# Altium schematic sheet POWER.SchDoc(POWER)
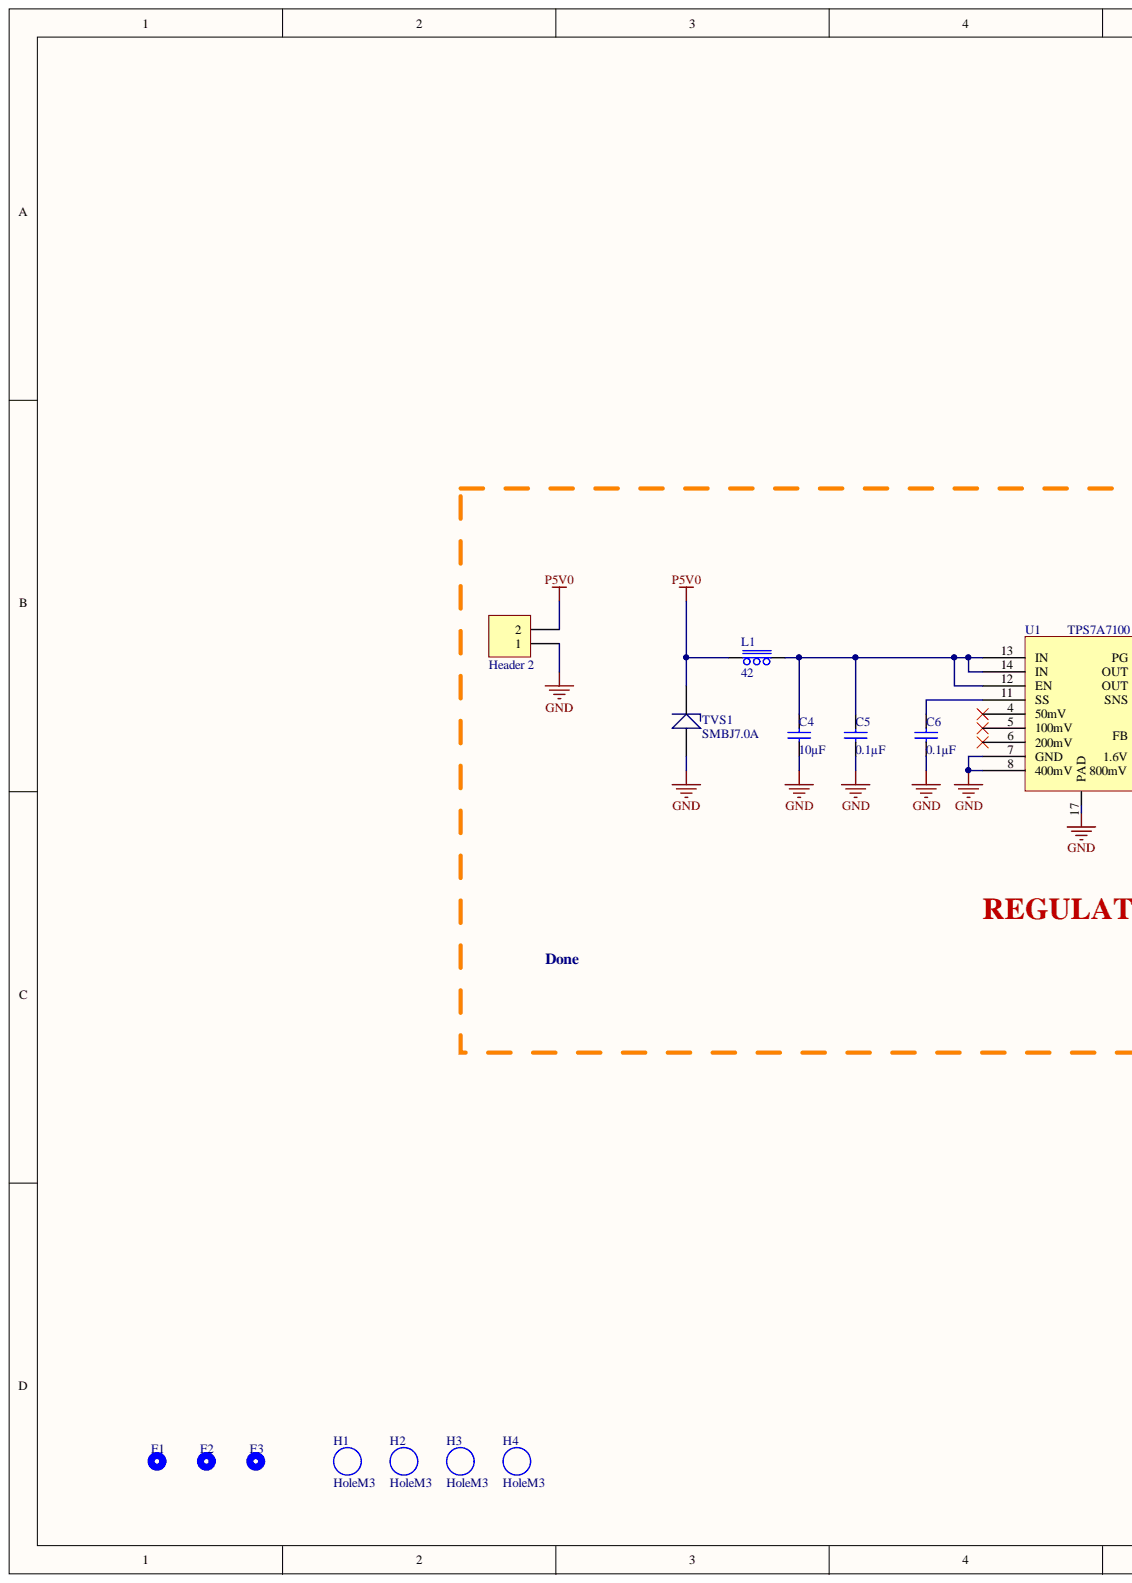
[diagram p0: POWER.SchDoc, left]
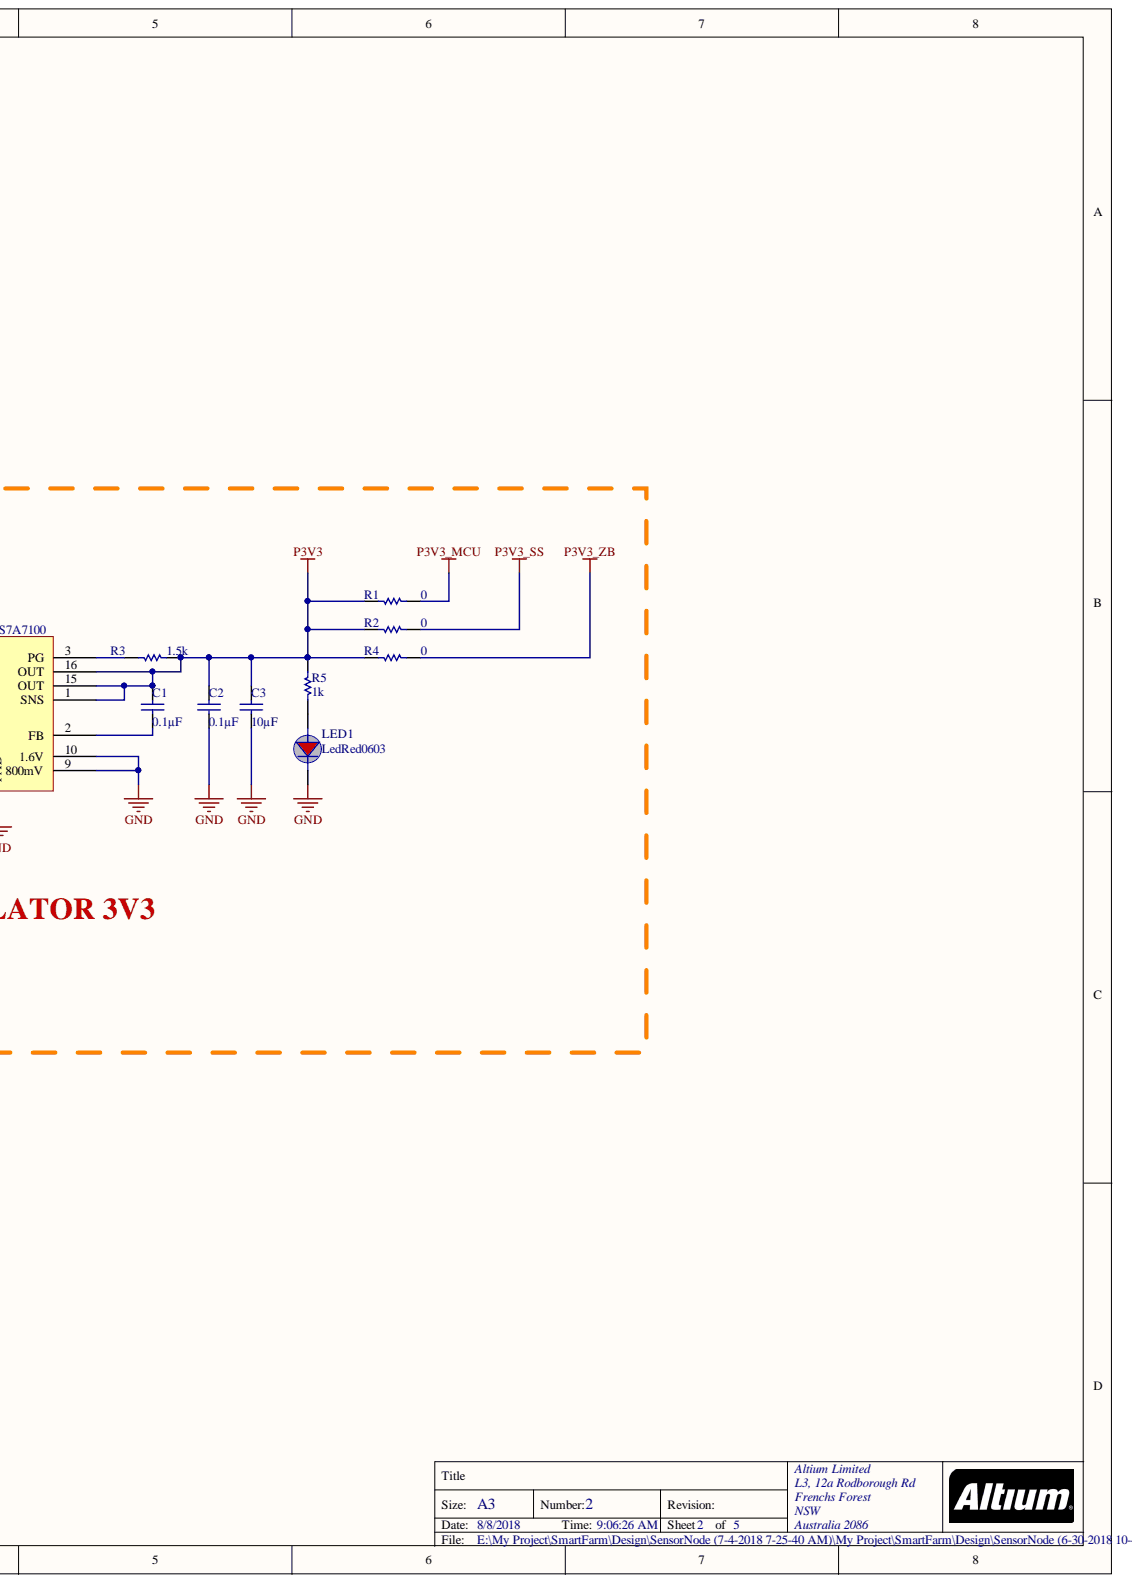
[diagram p1: POWER.SchDoc, right]

## Parts
C1  0.1µF  [C0402M]
C2  0.1µF  [C0402M]
C3  10µF  [0805 cap]
C4  10µF  [0805 cap]
C5  0.1µF  [C0402M]
C6  0.1µF  [C0402M]
F1  (no value)  [fiducials]
F2  (no value)  [fiducials]
F3  (no value)  [fiducials]
H1  HoleM3  [HOLEM3via]
H2  HoleM3  [HOLEM3via]
H3  HoleM3  [HOLEM3via]
H4  HoleM3  [HOLEM3via]
L1  42  [0805F]
LED1  LedRed0603  [0603LED-RED]
R1  0  [R0805M]
R2  0  [R0805M]
R3  1.5k  [R0603M]
R4  0  [R0805M]
R5  1k  [R0603M]
TVS1  SMBJ7.0A  [DIOM4436X26N]
U1  TPS7A7100  [QFN16]
  Header 2  [HED2T]

## Nets
GND: C2.1 C3.1 C4.1 C5.1 C6.1 LED1.2 TVS1.1 U1.7(GND) U1.8(400mV) U1.9(800mV) U1.10(1.6V) U1.17(PAD) .1
P3V3: C1.2 C2.2 C3.2 R1.1 R2.1 R3.2 R4.1 R5.2 U1.1(SNS) U1.15(OUT) U1.16(OUT)
P3V3_MCU: R1.2
P3V3_SS: R2.2
P3V3_ZB: R4.2
P5V0: L1.1 TVS1.2 .2
NetC1_1: C1.1 U1.2(FB)
NetC4_2: C4.2 C5.2 L1.2 U1.12(EN) U1.13(IN) U1.14(IN)
NetC6_2: C6.2 U1.11(SS)
NetLED1_1: LED1.1 R5.1
NetR3_1: R3.1 U1.3(PG)

Not connected: U1 4(50mV) 5(100mV) 6(200mV)

## Library data of the parts
C1 C2 C5 C6 = CAP0.1u0402: CAP CER 0.1UF 10V X7R 0402; Manufacturer Part Number: LMK105B7104KVHF; Manufacturer: Taiyo Yuden; Tolerance: ±10%; Voltage - Rated: 10V; Thickness (Max): 0.022" (0.55mm); Temperature Coefficient: X7R; Supplier Part Number 1: 587-3769-1-ND; Supplier 1: Digi-Key; Stock 1: 23930; Size / Dimension: 0.039" L x 0.020" W (1.00mm x 0.50mm); RoHS: RoHS Compliant; Ratings: AEC-Q200; Pricing 1: 1=0.1, 10=0.05, 100=0.0223, 500=0.01594, 1000=0.01252, 2500=0.01138, 5000=0.01047 (USD); Part Status: Active; Packaging: Cut Tape (CT); Package / Case: 0402 (1005 Metric); Operating Temperature: -55°C ~ 125°C; Mounting Type: Surface Mount, MLCC; Lead Style: -; Lead Spacing: -; Height - Seated (Max): -; Features: -; ComponentLink1URL: http://www.yuden.co.jp/productdata/catalog/en/mlcc_all_hq_e.pdf; ComponentLink1Description: http://www.yuden.co.jp/productdata/catalog/en/mlcc_all_hq_e.pdf; Component Kind: Standard; Category: Capacitors; Capacitance: 0.1µF; Applications: Automotive; Library Name: BinhLib.IntLib
C3 C4 = cap10u0805: CAP CER 10UF 16V X7R 0805; Manufacturer Part Number: EMK212BB7106MG-T; Manufacturer: Taiyo Yuden; Tolerance: ±20%; Voltage - Rated: 16V; Thickness (Max): 0.053" (1.35mm); Temperature Coefficient: X7R; Supplier Part Number 1: 587-3319-1-ND; Supplier 1: Digi-Key; Stock 1: 221600; Size / Dimension: 0.079" L x 0.049" W (2.00mm x 1.25mm); RoHS: RoHS Compliant; Ratings: -; Pricing 1: 1=0.51, 10=0.351, 100=0.2106, 500=0.15798, 1000=0.1334 (USD); Part Status: Active; Packaging: Cut Tape (CT); Package / Case: 0805 (2012 Metric); Operating Temperature: -55°C ~ 125°C; Mounting Type: Surface Mount, MLCC; Lead Style: -; Lead Spacing: -; Height - Seated (Max): -; Features: -; ComponentLink1URL: http://www.yuden.co.jp/productdata/catalog/en/mlcc06_e.pdf; ComponentLink1Description: http://www.yuden.co.jp/productdata/catalog/en/mlcc06_e.pdf; Component Kind: Standard; Category: Capacitors; Capacitance: 10µF; Applications: General Purpose; Library Name: BinhLib.IntLib
F1-F3 = Fiducials: Component Kind: Standard; Library Name: BinhLib.IntLib
L1 = Ferrite Bead: FERRITE BEAD 42 OHM 0805 1LN; Manufacturer Part Number: FBMJ2125HS420-TV; Manufacturer: Taiyo Yuden; Number of Lines: 1; Impedance @ Frequency: 42 Ohm @ 100MHz; Filter Type: Power Line; DC Resistance (DCR) (Max): 8 mOhm; Current Rating (Max): 4A; Supplier Part Number 1: 587-3851-6-ND; Supplier 1: Digi-Key; Stock 1: 2013; Size / Dimension: 0.079" L x 0.049" W (2.00mm x 1.25mm); RoHS: RoHS Compliant; Ratings: AEC-Q200; Pricing 1: 1=0.2, 10=0.154, 25=0.1276, 50=0.11, 100=0.099, 250=0.0836, 500=0.0748, 1000=0.0638 (USD); Part Status: Active; Packaging: Digi-Reel®; Package / Case: 0805 (2012 Metric); Operating Temperature: -40°C ~ 125°C; Mounting Type: Surface Mount; Height (Max): 0.041" (1.05mm); ComponentLink1URL: http://ds.yuden.co.jp/TYCOMPAS/ut/detail.do?productNo=FBMJ2125HS420-TV&fileName=FBMJ2125HS420-TV_SS&mode=specSheetDownload; ComponentLink1Description: http://ds.yuden.co.jp/TYCOMPAS/ut/detail.do?productNo=FBMJ2125HS420-TV&fileName=FBMJ2125HS420-TV_SS&mode=specSheetDownload; Component Kind: Standard; Category: Filters; Library Name: BinhLib.IntLib
LED1 = LedRed0603: LED RED CLEAR 0603 SMD; Manufacturer Part Number: QTLP600C7TR; Manufacturer: Everlight Electronics Co Ltd; Wavelength - Peak: 660nm; Wavelength - Dominant: 645nm; Voltage - Forward (Vf) (Typ): 1.9V; Viewing Angle: 100°; Millicandela Rating: 20mcd; Lens Style/Size: Rectangle with Flat Top, 1.20mm x 0.80mm; Lens Color: Colorless; Current - Test: 20mA; Supplier Part Number 1: 1080-1400-1-ND; Supplier 1: Digi-Key; Stock 1: 19325; Size / Dimension: 1.60mm L x 0.80mm W; RoHS: RoHS Compliant; Pricing 1: 1=0.41, 10=0.297, 25=0.2276, 100=0.1485, 250=0.10892, 500=0.0924, 1000=0.0759 (USD); Part Status: Active; Packaging: Cut Tape (CT); Package / Case: 0603 (1608 Metric); Mounting Type: Surface Mount; Lens Transparency: Clear; Height (Max): 0.80mm; Features: -; ComponentLink1URL: http://media.digikey.com/pdf/Data%20Sheets/Everlight%20PDFs/QTLP600C.pdf; ComponentLink1Description: http://media.digikey.com/pdf/Data%20Sheets/Everlight%20PDFs/QTLP600C.pdf; Component Kind: Standard; Color: Red; Category: Optoelectronics; Library Name: BinhLib.IntLib
R1 R2 R4 = RES00805: RES SMD 0.0 OHM JUMPER 1/8W 0805; Manufacturer Part Number: ERJ-6GEY0R00V; Manufacturer: Panasonic Electronic Components; Resistance (Ohms): 0.0; Power (Watts): 0.125W, 1/8W; Tolerance: Jumper; Temperature Coefficient: -; Supplier Part Number 1: P0.0ACT-ND; Supplier Device Package: 0805; Supplier 1: Digi-Key; Stock 1: 562130; Size / Dimension: 0.079" L x 0.049" W (2.00mm x 1.25mm); RoHS: RoHS Compliant; Pricing 1: 1=0.1, 10=0.024, 25=0.0172, 50=0.0132, 100=0.0097, 250=0.00744, 500=0.00594, 1000=0.00437 (USD); Part Status: Active; Packaging: Cut Tape (CT); Package / Case: 0805 (2012 Metric); Operating Temperature: -55°C ~ 155°C; Height - Seated (Max): 0.028" (0.70mm); Features: Automotive AEC-Q200; Failure Rate: -; Composition: Thick Film; ComponentLink1URL: http://industrial.panasonic.com/www-cgi/jvcr13pz.cgi?E+PZ+3+AOA0001+ERJ6GEY0R00V+7+WW; ComponentLink1Description: http://industrial.panasonic.com/www-cgi/jvcr13pz.cgi?E+PZ+3+AOA0001+ERJ6GEY0R00V+7+WW; Component Kind: Standard; Category: Resistors; Library Name: BinhLib.IntLib
R3 = RES1k50603: RES SMD 1.5K OHM 1% 1/10W 0603; Manufacturer Part Number: ERJ-3EKF1501V; Manufacturer: Panasonic Electronic Components; Temperature Coefficient: ±100ppm/°C; Power (Watts): 0.1W, 1/10W; Tolerance: ±1%; Supplier Part Number 1: P1.50KHCT-ND; Supplier Device Package: 0603; Supplier 1: Digi-Key; Stock 1: 0; Size / Dimension: 0.063" L x 0.031" W (1.60mm x 0.80mm); RoHS: RoHS Compliant; Resistance (Ohms): 1.5k; Pricing 1: 1=0.1, 10=0.021, 100=0.0087, 1000=0.00389, 2500=0.00338 (USD); Part Status: Active; Packaging: Cut Tape (CT); Package / Case: 0603 (1608 Metric); Operating Temperature: -55°C ~ 155°C; Height - Seated (Max): 0.022" (0.55mm); Features: Automotive AEC-Q200; Failure Rate: -; Composition: Thick Film; ComponentLink1URL: https://industrial.panasonic.com/cdbs/www-data/pdf/RDA0000/AOA0000C298.pdf; ComponentLink1Description: https://industrial.panasonic.com/cdbs/www-data/pdf/RDA0000/AOA0000C298.pdf; Component Kind: Standard; Category: Resistors; Library Name: BinhLib.IntLib
R5 = RES1k0603: RES SMD 1K OHM 1% 1/10W 0603; Manufacturer Part Number: ERJ-3EKF1001V; Manufacturer: Panasonic Electronic Components; Temperature Coefficient: ±100ppm/°C; Power (Watts): 0.1W, 1/10W; Tolerance: ±1%; Supplier Part Number 1: P1.00KHDKR-ND; Supplier Device Package: 0603; Supplier 1: Digi-Key; Stock 1: 0; Size / Dimension: 0.063" L x 0.031" W (1.60mm x 0.80mm); RoHS: RoHS Compliant; Resistance (Ohms): 1k; Pricing 1: 1=0.1, 10=0.021, 100=0.0087, 1000=0.00389, 2500=0.00338 (USD); Part Status: Active; Packaging: Digi-Reel®; Package / Case: 0603 (1608 Metric); Operating Temperature: -55°C ~ 155°C; Height - Seated (Max): 0.022" (0.55mm); Features: Automotive AEC-Q200; Failure Rate: -; Composition: Thick Film; ComponentLink1URL: https://industrial.panasonic.com/cdbs/www-data/pdf/RDA0000/AOA0000C298.pdf; ComponentLink1Description: https://industrial.panasonic.com/cdbs/www-data/pdf/RDA0000/AOA0000C298.pdf; Component Kind: Standard; Category: Resistors; Library Name: BinhLib.IntLib
TVS1 = TVS: TVS DIODE 7V 12V DO214AA; Manufacturer 1: Littelfuse Inc.; Voltage - Breakdown (Min): 7.78V; Power Line Protection: No; Power - Peak Pulse: 600W; Current - Peak Pulse (10/1000µs): 50A; Category 1: Circuit Protection; Voltage - Reverse Standoff (Typ): 7V; Voltage - Clamping (Max) @ Ipp: 12V; Type: Zener; Supplier Part Number 1: SMBJ7.0ALFCT-ND; Supplier Device Package: DO-214AA (SMBJ); Supplier 1: Digi-Key; Stock 1: 18983; RoHS 1: RoHS Compliant; Pricing 1: 1=0.42, 10=0.327, 25=0.2756, 100=0.2244, 250=0.18588, 500=0.15384, 1000=0.11538 (USD); Part Status: Active; Packaging: Cut Tape (CT); Package / Case: DO-214AA, SMB; Operating Temperature: -65°C ~ 150°C (TJ); Mounting Type: Surface Mount; Manufacturer Part Number 1: SMBJ7.0A; Library Name: SmartHome.SchLib; Description 1: TVS DIODE 7V 12V DO214AA; ComponentLink1URL: http://www.littelfuse.com/~/media/electronics/datasheets/tvs_diodes/littelfuse_tvs_diode_smbj_datasheet.pdf.pdf; ComponentLink1Description: http://www.littelfuse.com/~/media/electronics/datasheets/tvs_diodes/littelfuse_tvs_diode_smbj_datasheet.pdf.pdf; Component Kind: Standard; Capacitance @ Frequency: -; Applications: General Purpose
U1 = TPS7A7100: IC REG LDO FIX/ADJ 1A 16QFN; Manufacturer Part Number: TPS7A7100RGTR; Manufacturer: Texas Instruments; Voltage - Output: 0.9 V ~ 5 V; Voltage - Input: 1.425 V ~ 6.5 V; Regulator Topology: Positive Fixed or Adjustable; Current - Limit (Min): 1.1A; Voltage - Dropout (Typical): -; Supplier Part Number 1: 296-40978-1-ND; Supplier Device Package: 16-QFN (3x3); Supplier 1: Digi-Key; Stock 1: 5133; RoHS: RoHS Compliant; Pricing 1: 1=2.19, 10=1.965, 100=1.5796, 500=1.2978, 1000=1.07532 (USD); Part Status: Active; Packaging: Cut Tape (CT); Package / Case: 16-VFQFN Exposed Pad; Operating Temperature: -40°C ~ 125°C; Mounting Type: Surface Mount; Current - Output: 1A; ComponentLink1URL: http://www.ti.com/lit/ds/symlink/tps7a7100.pdf; ComponentLink1Description: http://www.ti.com/lit/ds/symlink/tps7a7100.pdf; Component Kind: Standard; Category: Integrated Circuits (ICs); Library Name: BinhLib.IntLib
 = Header 2: Header, 2-Pin; Signal Integrity: Connector; LatestRevisionNote: Re-released for DXP Platform.; LatestRevisionDate: 17-Jul-2002; Component Kind: Standard; Publisher: Altium Limited; Library Name: BinhLib.IntLib
H1-H4 = HoleM3: Component Kind: Standard; Library Name: BinhLib.IntLib
